# Altium schematic sheet Audio_Inputs.SchDoc
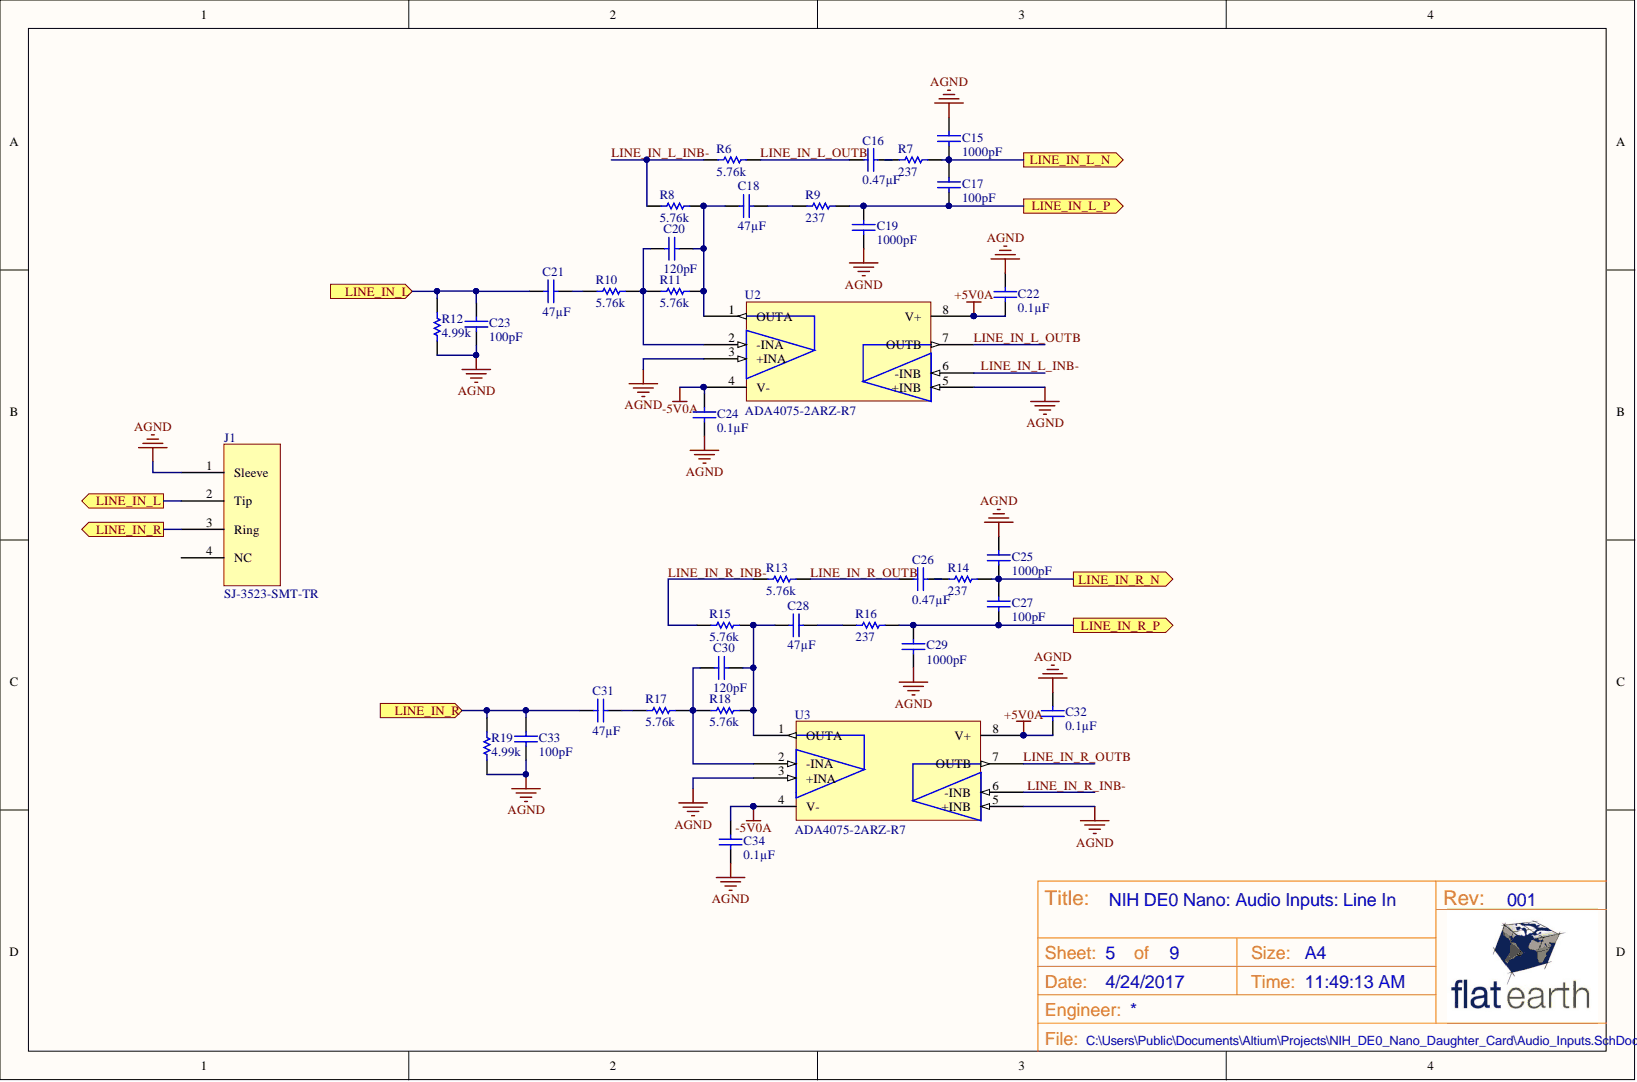
[diagram p0: Audio_Inputs.SchDoc]

## Parts
C15  Capacitance: 1000pF  [3-0603_L]  (hidden comment: CL10B102KB8NNNC)
C16  Capacitance: 0.47µF  [3-0603_L]  (hidden comment: C1608X7R1H474K080AC)
C17  Capacitance: 100pF  [3-0603_L]  (hidden comment: C1608C0G2A101J)
C18  Capacitance: 47µF  [C1210X25_L]  (hidden comment: GRM32EC81C476KE15L)
C19  Capacitance: 1000pF  [3-0603_L]  (hidden comment: CL10B102KB8NNNC)
C20  Capacitance: 120pF  [3-0603_L]  (hidden comment: CL10C121JB8NCNC)
C21  Capacitance: 47µF  [C1210X25_L]  (hidden comment: GRM32EC81C476KE15L)
C22  Capacitance: 0.1µF  [3-0603_L]  (hidden comment: C1608X7R1H104K)
C23  Capacitance: 100pF  [3-0603_L]  (hidden comment: C1608C0G2A101J)
C24  Capacitance: 0.1µF  [3-0603_L]  (hidden comment: C1608X7R1H104K)
C25  Capacitance: 1000pF  [3-0603_L]  (hidden comment: CL10B102KB8NNNC)
C26  Capacitance: 0.47µF  [3-0603_L]  (hidden comment: C1608X7R1H474K080AC)
C27  Capacitance: 100pF  [3-0603_L]  (hidden comment: C1608C0G2A101J)
C28  Capacitance: 47µF  [C1210X25_L]  (hidden comment: GRM32EC81C476KE15L)
C29  Capacitance: 1000pF  [3-0603_L]  (hidden comment: CL10B102KB8NNNC)
C30  Capacitance: 120pF  [3-0603_L]  (hidden comment: CL10C121JB8NCNC)
C31  Capacitance: 47µF  [C1210X25_L]  (hidden comment: GRM32EC81C476KE15L)
C32  Capacitance: 0.1µF  [3-0603_L]  (hidden comment: C1608X7R1H104K)
C33  Capacitance: 100pF  [3-0603_L]  (hidden comment: C1608C0G2A101J)
C34  Capacitance: 0.1µF  [3-0603_L]  (hidden comment: C1608X7R1H104K)
J1  SJ-3523-SMT-TR  [SJ-3523-SMT-TR]
R6  Resistance (Ohms): 5.76k  [3-0603_R_L]  (hidden comment: ERJ-3EKF5761V)
R7  ERJ-3EKF2370V  [3-0603_R_L]  (value not drawn)
R8  Resistance (Ohms): 5.76k  [3-0603_R_L]  (hidden comment: ERJ-3EKF5761V)
R9  ERJ-3EKF2370V  [3-0603_R_L]  (value not drawn)
R10  Resistance (Ohms): 5.76k  [3-0603_R_L]  (hidden comment: ERJ-3EKF5761V)
R11  Resistance (Ohms): 5.76k  [3-0603_R_L]  (hidden comment: ERJ-3EKF5761V)
R12  Resistance (Ohms): 4.99k  [3-0603_R_L]  (hidden comment: ERJ-3EKF4991V)
R13  Resistance (Ohms): 5.76k  [3-0603_R_L]  (hidden comment: ERJ-3EKF5761V)
R14  ERJ-3EKF2370V  [3-0603_R_L]  (value not drawn)
R15  Resistance (Ohms): 5.76k  [3-0603_R_L]  (hidden comment: ERJ-3EKF5761V)
R16  ERJ-3EKF2370V  [3-0603_R_L]  (value not drawn)
R17  Resistance (Ohms): 5.76k  [3-0603_R_L]  (hidden comment: ERJ-3EKF5761V)
R18  Resistance (Ohms): 5.76k  [3-0603_R_L]  (hidden comment: ERJ-3EKF5761V)
R19  Resistance (Ohms): 4.99k  [3-0603_R_L]  (hidden comment: ERJ-3EKF4991V)
U2  ADA4075-2ARZ-R7  [SOIC127P600X175-8L]
U3  ADA4075-2ARZ-R7  [SOIC127P600X175-8L]

## Ports
LINE_IN_L, LINE_IN_L_N, LINE_IN_L_P, LINE_IN_R, LINE_IN_R_N, LINE_IN_R_P

## Nets
+5V0A: C22.1 C32.1 U2.8(V+) U3.8(V+)
-5V0A: C24.2 C34.1 U2.4(V-) U3.4(V-)
AGND: C15.1 C19.1 C22.2 C23.1 C24.1 C25.1 C29.1 C32.2 C33.1 C34.2 J1.1(Sleeve) R12.1 R19.1 U2.3(+INA) U2.5(+INB) U3.3(+INA) U3.5(+INB)
LINE_IN_L: C21.1 C23.2 J1.2(Tip) R12.2 ; port LINE_IN_L
LINE_IN_L_INB-: R6.1 R8.1 U2.6(-INB)
LINE_IN_L_N: C15.2 C17.2 R7.2 ; port LINE_IN_L_N
LINE_IN_L_OUTB: C16.1 R6.2 U2.7(OUTB)
LINE_IN_L_P: C17.1 C19.2 R9.2 ; port LINE_IN_L_P
LINE_IN_R: C31.1 C33.2 J1.3(Ring) R19.2 ; port LINE_IN_R
LINE_IN_R_INB-: R13.1 R15.1 U3.6(-INB)
LINE_IN_R_N: C25.2 C27.2 R14.2 ; port LINE_IN_R_N
LINE_IN_R_OUTB: C26.1 R13.2 U3.7(OUTB)
LINE_IN_R_P: C27.1 C29.2 R16.2 ; port LINE_IN_R_P
NetC16_2: C16.2 R7.1
NetC18_1: C18.1 C20.2 R8.2 R11.2 U2.1(OUTA)
NetC18_2: C18.2 R9.1
NetC20_1: C20.1 R10.2 R11.1 U2.2(-INA)
NetC21_2: C21.2 R10.1
NetC26_2: C26.2 R14.1
NetC28_1: C28.1 C30.2 R15.2 R18.2 U3.1(OUTA)
NetC28_2: C28.2 R16.1
NetC30_1: C30.1 R17.2 R18.1 U3.2(-INA)
NetC31_2: C31.2 R17.1

Not connected: J1 4(NC)

## Library data of the parts
C15 C19 C25 C29 = CL10B102KB8NNNC: 1000pF 50V Ceramic Capacitor X7R 0603 (1608 Metric) 0.063" L x 0.031" W (1.60mm x 0.80mm); Manufacturer: Samsung Electro-Mechanics America, Inc.; Tolerance: ±10%; Applications: General Purpose; Category: Capacitors; Component Kind: Standard; ComponentLink1Description: http://www.samsungsem.com/kr/support/product-search/mlcc/__icsFiles/afieldfile/2015/06/15/CL10B102KB8NNNC.pdf; ComponentLink1URL: http://www.samsungsem.com/kr/support/product-search/mlcc/__icsFiles/afieldfile/2015/06/15/CL10B102KB8NNNC.pdf; Features: -; Height - Seated (Max): -; Lead Spacing: -; Lead Style: -; Library Name: Schematic_Components.SchLib; Manufacturer Part Number: CL10B102KB8NNNC; Mounting Type: Surface Mount, MLCC; Operating Temperature: -55°C ~ 125°C; Package / Case: 0603 (1608 Metric); Packaging: Cut Tape (CT); Part Status: Active; Pricing 1: 1=0.1, 10=0.017, 25=0.012, 50=0.0092, 100=0.0077, 500=0.0054, 1000=0.0042 (USD); Ratings: -; RoHS: RoHS Compliant; Size / Dimension: 0.063" L x 0.031" W (1.60mm x 0.80mm); Stock 1: 294007; Supplier 1: Digi-Key; Supplier Part Number 1: 1276-1018-1-ND; Temperature Coefficient: X7R; Thickness (Max): 0.035" (0.90mm); Voltage - Rated: 50V
C16 C26 = C1608X7R1H474K080AC: CAP CER 0.47UF 50V 10% X7R 0603; Manufacturer: TDK Corporation; Applications: General Purpose; Category: Capacitors; Component Kind: Standard; ComponentLink1Description: http://product.tdk.com/capacitor/mlcc/en/documents/mlcc_commercial_general_en.pdf; ComponentLink1URL: http://product.tdk.com/capacitor/mlcc/en/documents/mlcc_commercial_general_en.pdf; Features: -; Height - Seated (Max): -; Lead Spacing: -; Lead Style: -; Library Name: Schematic_Components.SchLib; Manufacturer Part Number: C1608X7R1H474K080AC; Mounting Type: Surface Mount, MLCC; Operating Temperature: -55°C ~ 125°C; Package / Case: 0603 (1608 Metric); Packaging: Cut Tape (CT); Pricing 1: 1=0.24, 10=0.2, 100=0.1, 250=0.075, 500=0.06626, 1000=0.05875 (USD); Ratings: -; RoHS: RoHS Compliant; Size / Dimension: 0.063" L x 0.031" W (1.60mm x 0.80mm); Stock 1: 0; Supplier 1: Digi-Key; Supplier Part Number 1: 445-5952-1-ND; Temperature Coefficient: X7R; Thickness (Max): 0.037" (0.95mm); Tolerance: ±10%; Voltage - Rated: 50V
C17 C23 C27 C33 = C1608C0G2A101J: CAP CER 100PF 100V 5% NP0 0603; Manufacturer: TDK Corporation; Temperature Coefficient: C0G, NP0; Applications: General Purpose; Category: Capacitors; Component Kind: Standard; ComponentLink1Description: http://www.tdk.com/pdf/mid_volt_B11.pdf; ComponentLink1URL: http://www.tdk.com/pdf/mid_volt_B11.pdf; Features: -; Height - Seated (Max): -; Lead Spacing: -; Lead Style: -; Library Name: Schematic_Components.SchLib; Manufacturer Part Number: C1608C0G2A101J; Mounting Type: Surface Mount, MLCC; Operating Temperature: -55°C ~ 125°C; Package / Case: 0603 (1608 Metric); Packaging: Cut Tape (CT); Pricing 1: 10=0.05, 100=0.0231, 250=0.01892, 500=0.01618, 1000=0.0126 (USD); Ratings: -; RoHS: RoHS Compliant; Size / Dimension: 0.063" L x 0.031" W (1.60mm x 0.80mm); Stock 1: 128588; Supplier 1: Digi-Key; Supplier Part Number 1: 445-2306-1-ND; Thickness (Max): 0.037" (0.95mm); Tolerance: ±5%; Voltage - Rated: 100V
C18 C21 C28 C31 = GRM32EC81C476KE15L: 47µF ±10% 16V Ceramic Capacitor X6S 1210 (3225 Metric); Manufacturer: Murata Electronics North America; Applications: General Purpose; Category: Capacitors; Component Kind: Standard; ComponentLink1Description: http://www.murata.com/~/media/webrenewal/support/library/catalog/products/capacitor/mlcc/c02e.pdf; ComponentLink1URL: http://www.murata.com/~/media/webrenewal/support/library/catalog/products/capacitor/mlcc/c02e.pdf; Features: Derating Recommended; Height - Seated (Max): -; Lead Spacing: -; Lead Style: -; Library Name: Schematic_Components.SchLib; Manufacturer Part Number: GRM32EC81C476KE15L; Mounting Type: Surface Mount, MLCC; Operating Temperature: -55°C ~ 105°C; Package / Case: 1210 (3225 Metric); Packaging: Cut Tape (CT); Part Status: Active; Pricing 1: 1=0.73, 10=0.697, 25=0.4944, 50=0.4164, 100=0.3383, 250=0.28108, 500=0.25504 (USD); Ratings: -; RoHS: RoHS Compliant; Size / Dimension: 0.126" L x 0.098" W (3.20mm x 2.50mm); Stock 1: 39641; Supplier 1: Digi-Key; Supplier Part Number 1: 490-10531-1-ND; Temperature Coefficient: X6S; Thickness (Max): 0.106" (2.70mm); Tolerance: ±10%; Voltage - Rated: 16V
C20 C30 = CL10C121JB8NCNC: 120pF 50V Ceramic Capacitor C0G, NP0 0603 (1608 Metric) 0.063" L x 0.031" W (1.60mm x 0.80mm); Manufacturer: Samsung Electro-Mechanics America, Inc.; Tolerance: ±5%; Applications: General Purpose; Category: Capacitors; Component Kind: Standard; ComponentLink1Description: http://media.digikey.com/pdf/Data%20Sheets/Samsung%20PDFs/CL10C121JB8NCNC_Spec.pdf; ComponentLink1URL: http://media.digikey.com/pdf/Data%20Sheets/Samsung%20PDFs/CL10C121JB8NCNC_Spec.pdf; Features: -; Height - Seated (Max): -; Lead Spacing: -; Lead Style: -; Library Name: Schematic_Components.SchLib; Manufacturer Part Number: CL10C121JB8NCNC; Mounting Type: Surface Mount, MLCC; Operating Temperature: -55°C ~ 125°C; Package / Case: 0603 (1608 Metric); Packaging: Cut Tape (CT); Part Status: Active; Pricing 1: 1=0.1, 10=0.03, 25=0.0208, 50=0.016, 100=0.0135, 500=0.00948, 1000=0.00738 (USD); Ratings: -; RoHS: RoHS Compliant; Size / Dimension: 0.063" L x 0.031" W (1.60mm x 0.80mm); Stock 1: 26285; Supplier 1: Digi-Key; Supplier Part Number 1: 1276-2180-1-ND; Temperature Coefficient: C0G, NP0; Thickness (Max): 0.035" (0.90mm); Voltage - Rated: 50V
C22 C24 C32 C34 = C1608X7R1H104K: CAP CER 0.1UF 50V 10% X7R 0603; Manufacturer: TDK Corporation; Applications: General Purpose; Category: Capacitors; Component Kind: Standard; ComponentLink1Description: http://www.tdk.com/pdf/general_B11.pdf; ComponentLink1URL: http://www.tdk.com/pdf/general_B11.pdf; Features: -; Height - Seated (Max): -; Lead Spacing: -; Lead Style: -; Library Name: Schematic_Components.SchLib; Manufacturer Part Number: C1608X7R1H104K; Mounting Type: Surface Mount, MLCC; Operating Temperature: -55°C ~ 125°C; Package / Case: 0603 (1608 Metric); Packaging: Cut Tape (CT); Pricing 1: 10=0.048, 100=0.022, 250=0.018, 500=0.0154, 1000=0.012 (USD); Ratings: -; RoHS: RoHS Compliant; Size / Dimension: 0.063" L x 0.031" W (1.60mm x 0.80mm); Stock 1: 2442296; Supplier 1: Digi-Key; Supplier Part Number 1: 445-1314-1-ND; Temperature Coefficient: X7R; Thickness (Max): 0.037" (0.95mm); Tolerance: ±10%; Voltage - Rated: 50V
J1 = SJ-3523-SMT-TR: 3.50mm (0.141", 1/8", Mini Plug) - Headphone Phone Jack Stereo Connector Solder; Manufacturer: CUI Inc.; Actual Diameter: 0.142" (3.60mm); Body Color: Black; Current Rating: 1A; Gender: Female; Includes: 1 pc - 1 Connector; Internal Switch(s): Does Not Contain Switch; Mating Length/Depth: 0.551" (14.00mm); Number of Positions/Contacts: 3 Conductors, 3 Contacts; Shielding: Unshielded; Voltage - Rated: 12VDC; Body Material: -; Category: Connectors, Interconnects; Component Kind: Standard; ComponentLink1Description: http://www.cui.com/product/resource/digikeypdf/sj-352x-smt_series.pdf; ComponentLink1URL: http://www.cui.com/product/resource/digikeypdf/sj-352x-smt_series.pdf; Connector Type: Phone Jack; Contact Material - Plating: Silver; Features: Board Guide; Industry Recognized Mating Diameter: 3.50mm (0.141", 1/8", Mini Plug) - Headphone; Insulation Color: -; LatestRevisionDate: 17-Jul-2002; LatestRevisionNote: Re-released for DXP Platform.; Manufacturer Part Number: SJ-3523-SMT-TR; Mounting Type: Surface Mount, Right Angle; Packaging: Cut Tape (CT); Part Status: Active; Pricing 1: 1=1.34, 10=1.043, 100=0.7961, 500=0.63558 (USD); RoHS: RoHS Compliant; Signal Lines: Stereo; Stock 1: 104981; Supplier 1: Digi-Key; Supplier Part Number 1: CP-3523SJCT-ND; Termination: Solder; Library Name: NIH_DE0_Nano_Daughter_Card_Library.SchLib; Publisher: Altium Limited
R6 R8 R10 R11 R13 R15 R17 R18 = ERJ-3EKF5761V: RES SMD 5.76K OHM 1% 1/10W 0603; Manufacturer: Panasonic Electronic Components; Power (Watts): 0.1W, 1/10W; Temperature Coefficient: ±100ppm/°C; Category: Resistors; Component Kind: Standard; ComponentLink1Description: http://industrial.panasonic.com/www-cgi/jvcr13pz.cgi?E+PZ+3+AOA0002+ERJ3EKF5761V+7+WW; ComponentLink1URL: http://industrial.panasonic.com/www-cgi/jvcr13pz.cgi?E+PZ+3+AOA0002+ERJ3EKF5761V+7+WW; Composition: Thick Film; Features: Automotive AEC-Q200; Height: 0.022" (0.55mm); Library Name: Schematic_Components.SchLib; Manufacturer Part Number: ERJ-3EKF5761V; Number of Terminations: 2; Operating Temperature: -55°C ~ 155°C; Package / Case: 0603 (1608 Metric); Packaging: Cut Tape (CT); Part Status: Active; Pricing 1: 1=0.1, 50=0.0114, 100=0.0084, 250=0.00644, 500=0.00514, 1000=0.00379 (USD); RoHS: RoHS Compliant; Size / Dimension: 0.063" L x 0.031" W (1.60mm x 0.80mm); Stock 1: 90842; Supplier 1: Digi-Key; Supplier Device Package: 0603; Supplier Part Number 1: P5.76KHCT-ND; Tolerance: ±1%
R7 R9 R14 R16 = ERJ-3EKF2370V: RES SMD 237 OHM 1% 1/10W 0603; Manufacturer: Panasonic Electronic Components; Power (Watts): 0.1W, 1/10W; Resistance (Ohms): 237; Temperature Coefficient: ±100ppm/°C; Category: Resistors; Component Kind: Standard; ComponentLink1Description: http://industrial.panasonic.com/www-cgi/jvcr13pz.cgi?E+PZ+3+AOA0002+ERJ3EKF2370V+7+WW; ComponentLink1URL: http://industrial.panasonic.com/www-cgi/jvcr13pz.cgi?E+PZ+3+AOA0002+ERJ3EKF2370V+7+WW; Composition: Thick Film; Features: Automotive AEC-Q200; Height: 0.022" (0.55mm); Library Name: Schematic_Components.SchLib; Manufacturer Part Number: ERJ-3EKF2370V; Number of Terminations: 2; Operating Temperature: -55°C ~ 155°C; Package / Case: 0603 (1608 Metric); Packaging: Cut Tape (CT); Part Status: Active; Pricing 1: 1=0.1, 50=0.0114, 100=0.0084, 250=0.00644, 500=0.00514, 1000=0.00379 (USD); RoHS: RoHS Compliant; Size / Dimension: 0.063" L x 0.031" W (1.60mm x 0.80mm); Stock 1: 74413; Supplier 1: Digi-Key; Supplier Device Package: 0603; Supplier Part Number 1: P237HCT-ND; Tolerance: ±1%
R12 R19 = ERJ-3EKF4991V: RES SMD 4.99K OHM 1% 1/10W 0603; Manufacturer: Panasonic Electronic Components; Power (Watts): 0.1W, 1/10W; Temperature Coefficient: ±100ppm/°C; Category: Resistors; Component Kind: Standard; ComponentLink1Description: http://industrial.panasonic.com/www-cgi/jvcr13pz.cgi?E+PZ+3+AOA0002+ERJ3EKF4991V+7+WW; ComponentLink1URL: http://industrial.panasonic.com/www-cgi/jvcr13pz.cgi?E+PZ+3+AOA0002+ERJ3EKF4991V+7+WW; Composition: Thick Film; Features: Automotive AEC-Q200; Height: 0.022" (0.55mm); Library Name: Schematic_Components.SchLib; Manufacturer Part Number: ERJ-3EKF4991V; Number of Terminations: 2; Operating Temperature: -55°C ~ 155°C; Package / Case: 0603 (1608 Metric); Packaging: Cut Tape (CT); Part Status: Active; Pricing 1: 1=0.1, 50=0.0114, 100=0.0084, 250=0.00644, 500=0.00514, 1000=0.00379 (USD); RoHS: RoHS Compliant; Size / Dimension: 0.063" L x 0.031" W (1.60mm x 0.80mm); Stock 1: 870970; Supplier 1: Digi-Key; Supplier Device Package: 0603; Supplier Part Number 1: P4.99KHCT-ND; Tolerance: ±1%
U2 U3 = ADA4075-2ARZ-R7: IC OPAMP GP 6.5MHZ 8SOIC; Manufacturer: Analog Devices Inc.; Amplifier Type: General Purpose; Current - Input Bias: 30nA; Current - Output / Channel: 40mA; Current - Supply: 1.8mA; Number of Circuits: 2; Slew Rate: 12 V/µs; Voltage - Input Offset: 200µV; Voltage - Supply, Single/Dual (±): ±4.5 V ~ 18 V; -3db Bandwidth: -; Category: Integrated Circuits (ICs); Component Kind: Standard; ComponentLink1Description: http://www.analog.com/media/en/technical-documentation/data-sheets/ADA4075-2.pdf; ComponentLink1URL: http://www.analog.com/media/en/technical-documentation/data-sheets/ADA4075-2.pdf; Gain Bandwidth Product: 6.5MHz; Manufacturer Part Number: ADA4075-2ARZ-R7; Mounting Type: Surface Mount; Operating Temperature: -40°C ~ 125°C; Output Type: -; Package / Case: 8-SOIC (0.154", 3.90mm Width); Packaging: Cut Tape (CT); Part Status: Active; Pricing 1: 1=2.28, 10=2.05, 100=1.6441, 500=1.35136 (USD); RoHS: RoHS Compliant; Stock 1: 11196; Supplier 1: Digi-Key; Supplier Device Package: 8-SOIC; Supplier Part Number 1: ADA4075-2ARZ-R7CT-ND; Library Name: NIH_DE0_Nano_Daughter_Card_Library.SchLib
